# Altium schematic sheet FT231X_BRK.SchDoc
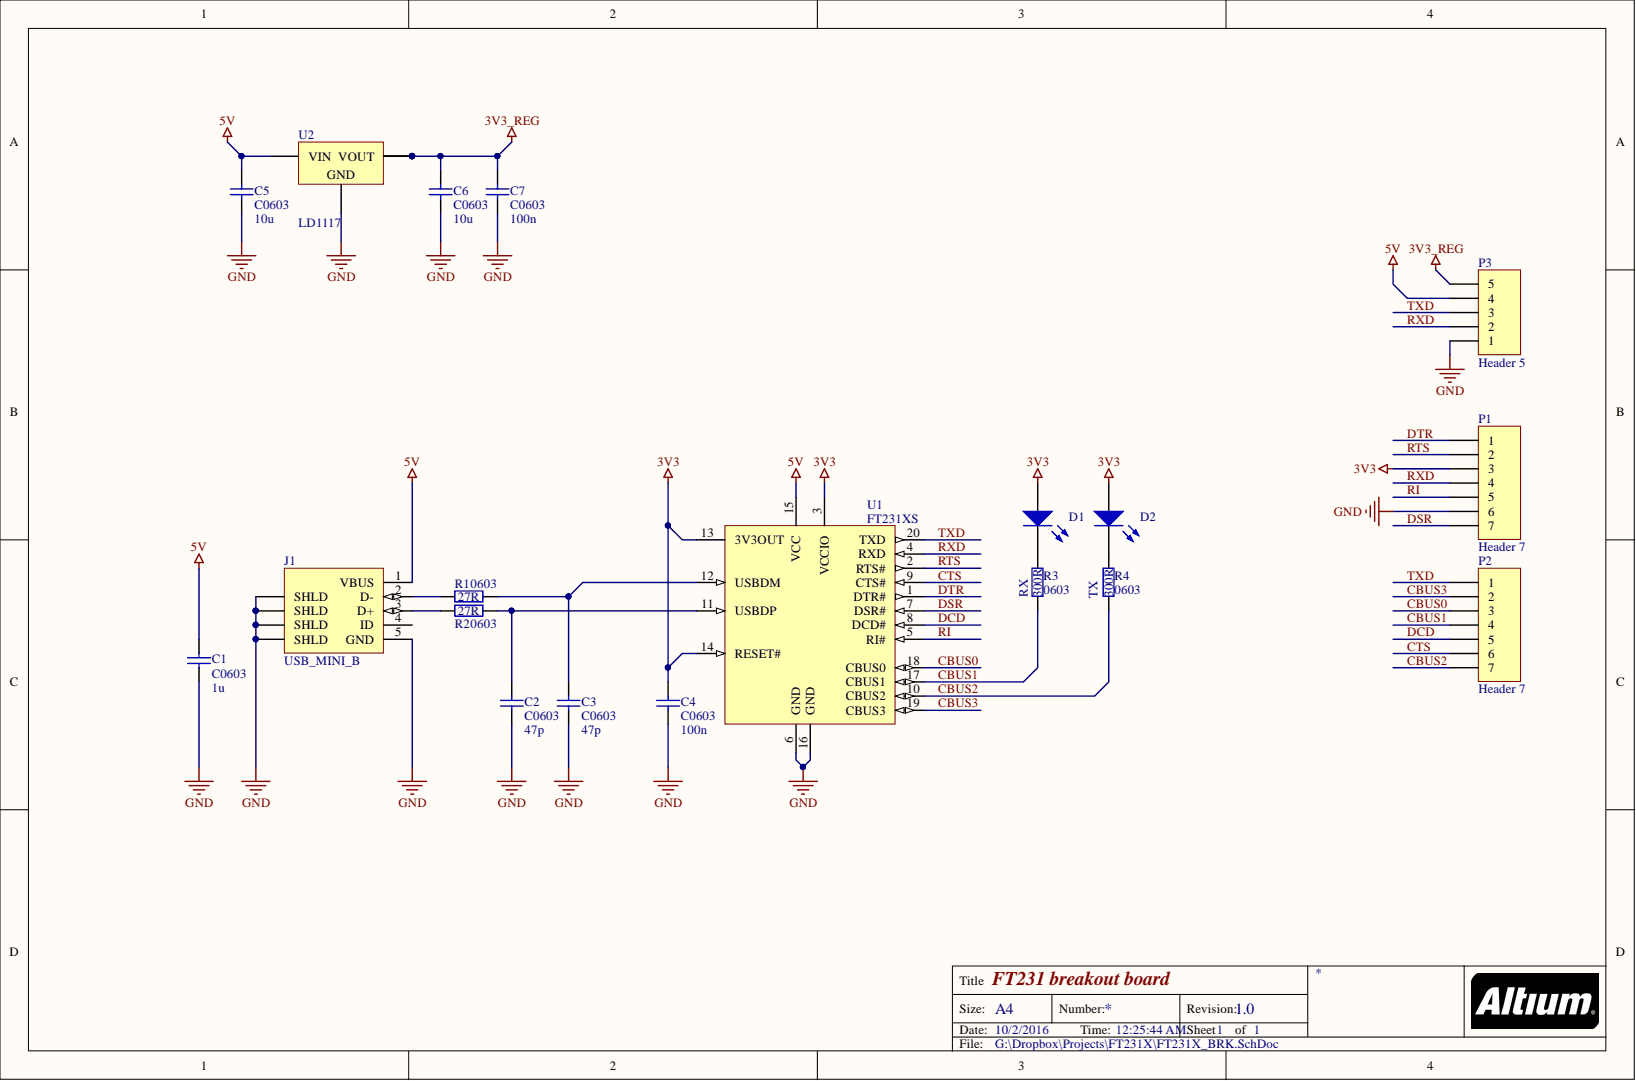
[diagram p0: FT231X_BRK.SchDoc]

## Parts
C1  1u  [C0603]
C2  47p  [C0603]
C3  47p  [C0603]
C4  100n  [C0603]
C5  10u  [C0603]
C6  10u  [C0603]
C7  100n  [C0603]
D1  LEDX  [LED 0805 Blue]  (value not drawn)
D2  LEDX  [LED 0805 Blue]  (value not drawn)
J1  USB_MINI_B  [USB_Mini_B_9.3x7.7]
P1  Header 7  [HEADER 1X7 MALE]
P2  Header 7  [HEADER 1X7 MALE]
P3  Header 5  [HEADER 1X5 MALE]
R1  27R  [0603]
R2  27R  [0603]
R3  300R  [0603]
R4  300R  [0603]
U1  FT231XS  [SSOP20_M]
U2  LD1117  [TO-252]

## Nets
3V3: C4.1 D1.1 D2.1 P1.3 U1.3(VCCIO) U1.13(3V3OUT) U1.14(RESET#)
3V3_REG: C6.1 C7.1 P3.5 U2.2(VOUT) U2.4 U2.5 U2.6
5V: C1.1 C5.1 J1.1(VBUS) P3.4 U1.15(VCC) U2.3(VIN)
GND: C1.2 C2.2 C3.2 C4.2 C5.2 C6.2 C7.2 J1.5(GND) J1.MH1(SHLD) J1.MH2(SHLD) J1.MH3(SHLD) J1.MH4(SHLD) P1.6 P3.1 U1.6(GND) U1.16(GND) U2.1
CBUS0: P2.3 U1.18(CBUS0)
CBUS1: P2.4 R3.2 U1.17(CBUS1)
CBUS2: P2.7 R4.2 U1.10(CBUS2)
CBUS3: P2.2 U1.19(CBUS3)
CTS: P2.6 U1.9(CTS#)
DCD: P2.5 U1.8(DCD#)
DSR: P1.7 U1.7(DSR#)
DTR: P1.1 U1.1(DTR#)
RI: P1.5 U1.5(RI#)
RTS: P1.2 U1.2(RTS#)
RXD: P1.4 P3.2 U1.4(RXD)
TXD: P2.1 P3.3 U1.20(TXD)
NetC2_1: C2.1 R2.2 U1.11(USBDP)
NetC3_1: C3.1 R1.2 U1.12(USBDM)
NetD1_2: D1.2 R3.1
NetD2_2: D2.2 R4.1
NetJ1_2: J1.2(D-) R1.1
NetJ1_3: J1.3(D+) R2.1

Not connected: J1 4(ID)

## Library data of the parts
C1-C7 = Cap: Generic capacitor; Component Kind: Standard; Library Name: _RLC.IntLib; Packge: C0603
  C1: Value: 1u
  C2 C3: Value: 47p
  C4 C7: Value: 100n
  C5 C6: Value: 10u
D1 D2 = LEDX: Typical LED; Component Kind: Standard; Library Name: _Diodes.IntLib; Simulation: LED0
J1 = USB_MINI_B2: USB On-The-Go (OTG) Mini-B Receptacle; Component Kind: Standard; Library Name: _Connectors.IntLib
P1 P2 = Header 7: Header, 7-Pin; Component Kind: Standard; LatestRevisionDate: 17-Jul-2002; LatestRevisionNote: Re-released for DXP Platform.; Library Name: _Connectors.IntLib; Publisher: Altium Limited
P3 = Header 5: Header, 5-Pin; Component Kind: Standard; LatestRevisionDate: 17-Jul-2002; LatestRevisionNote: Re-released for DXP Platform.; Library Name: _Connectors.IntLib; Publisher: Altium Limited
R1-R4 = Res: Generic resistor; Component Kind: Standard; Library Name: _RLC.IntLib; Package: 0603
  R1 R2: Value: 27R
  R3 R4: Value: 300R
U1 = FT231XS: USB to Full-Handshake UART Interface Chip, UHCI/OHCI/EHCI Compatible, USB 2.0 Compatible, -40 to +85 degC, 20-Pin SSOP, Pb-Free, Tube; Manufacturer: FTDI; PartNumber: FT231XS-U; Code_JEDEC: MO-137-AD; Component Kind: Standard; ComponentLink1Description: Manufacturer URL; ComponentLink1URL: http://www.ftdichip.com/; ComponentLink2Description: Datasheet; ComponentLink2URL: http://www.ftdichip.com/Support/Documents/DataSheets/ICs/DS_FT231X.pdf; ComponentLink3Description: FT231X Product Page; ComponentLink3URL: www.ftdichip.com/Products/ICs/FT231X.html; DatasheetVersion: ver 1.2, Feb-2013; PackageDescription: 20-Pin Small Outline Package, 8.6 x 3.9 mm Body, 0.635 mm Pitch; PackageReference: SSOP-20; PackageVersion: Feb-13; Library Name: _Microcircuits.IntLib
U2 = LD1117: Low drop positive voltage regulator; Component Kind: Standard; ComponentLink1Description: Datasheet; ComponentLink1URL: http://www.st.com/web/en/resource/technical/document/datasheet/CD00000544.pdf; Library Name: _Microcircuits.IntLib
